# Altium schematic sheet Sensors.SchDoc
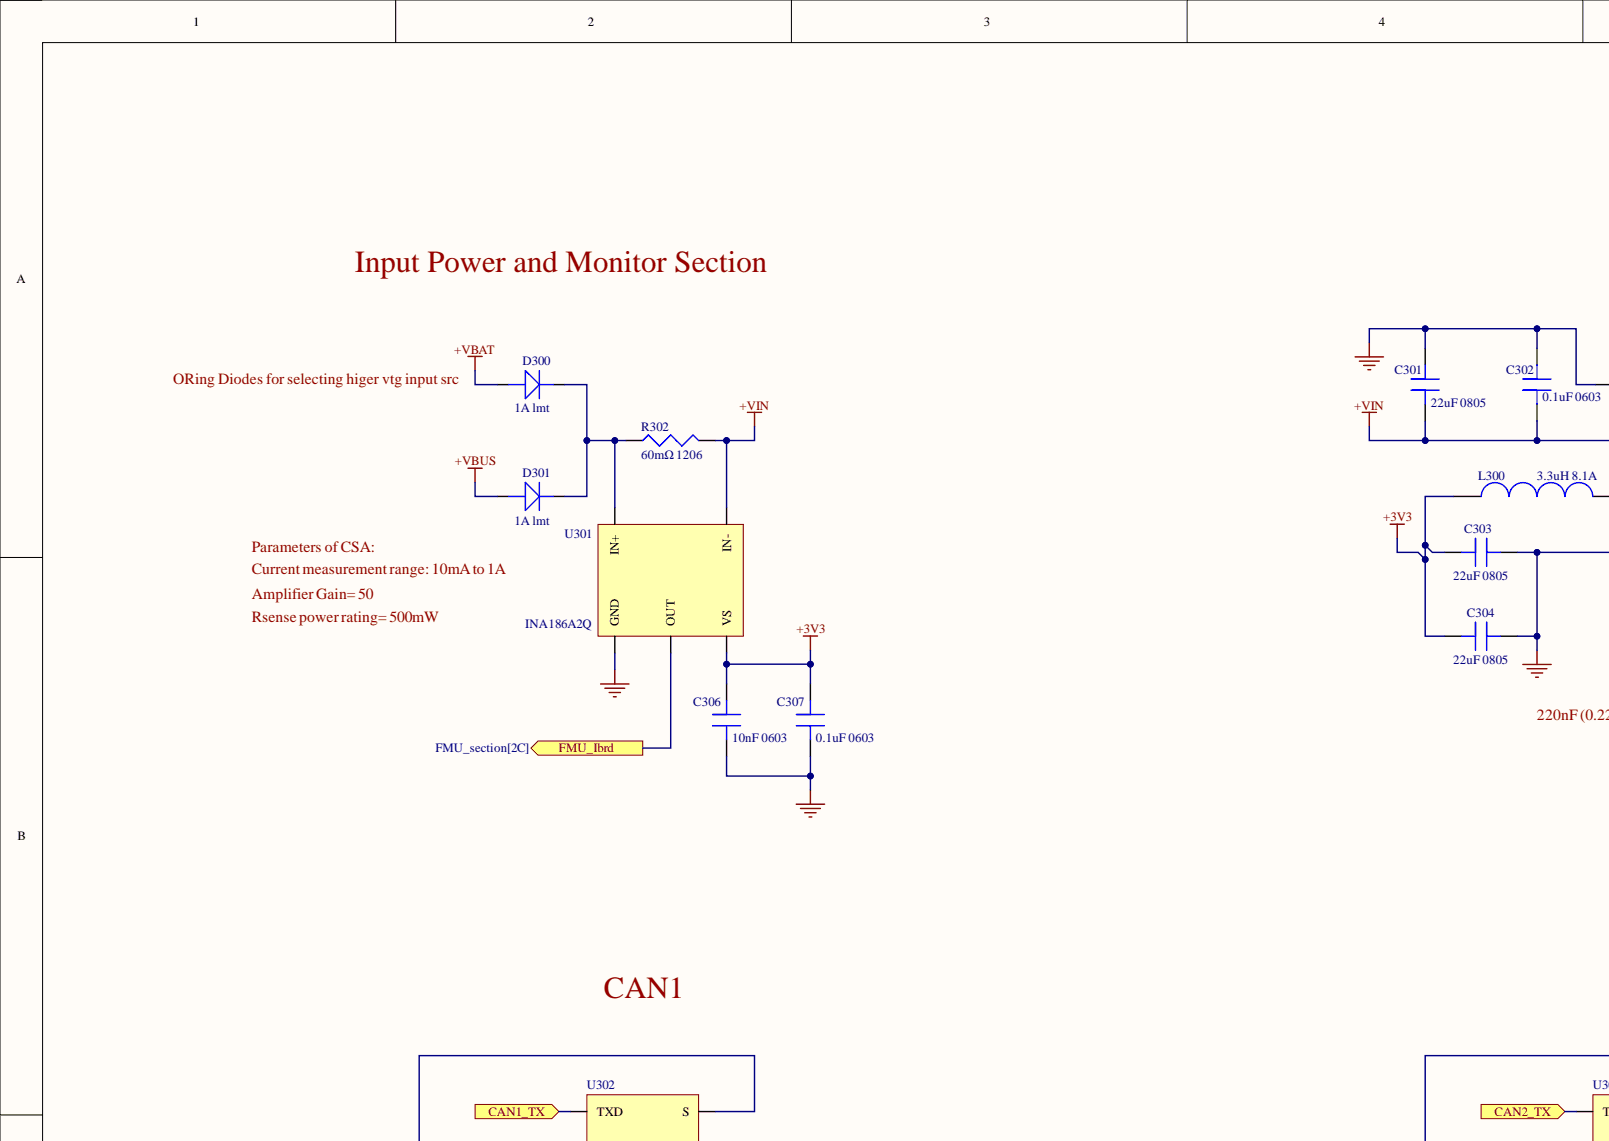
[diagram p0: Sensors.SchDoc, top left]
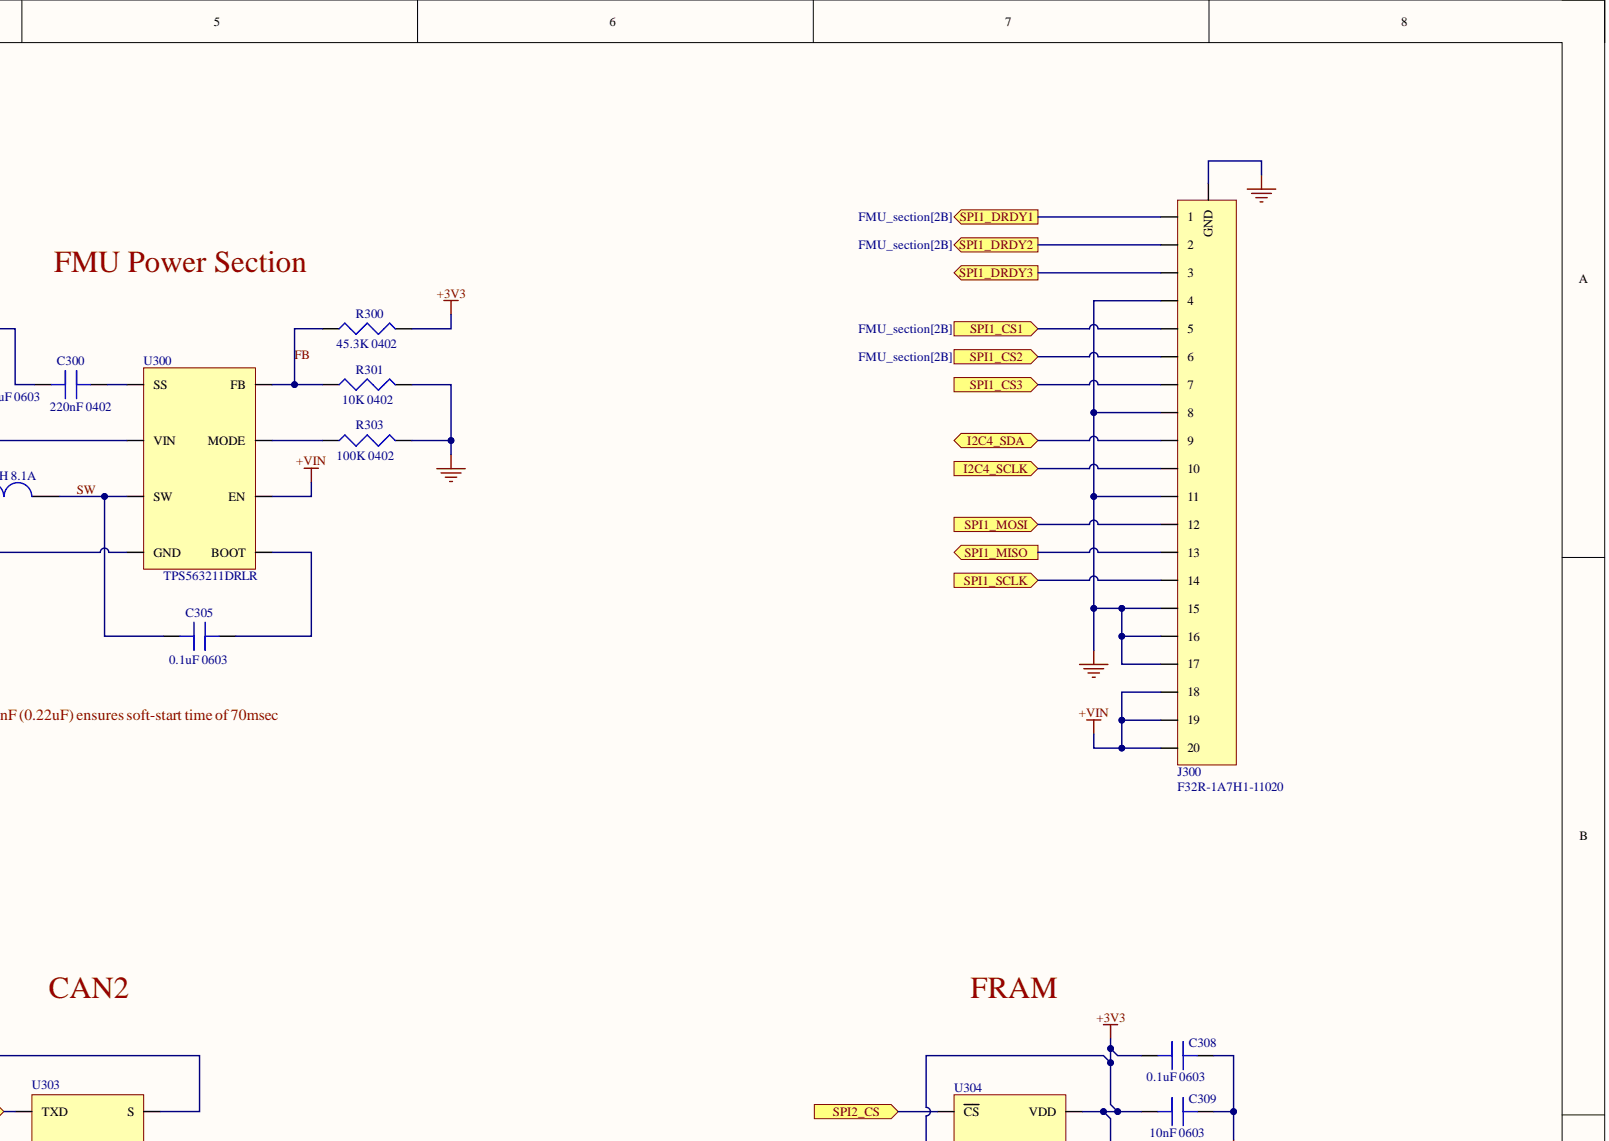
[diagram p1: Sensors.SchDoc, top right]
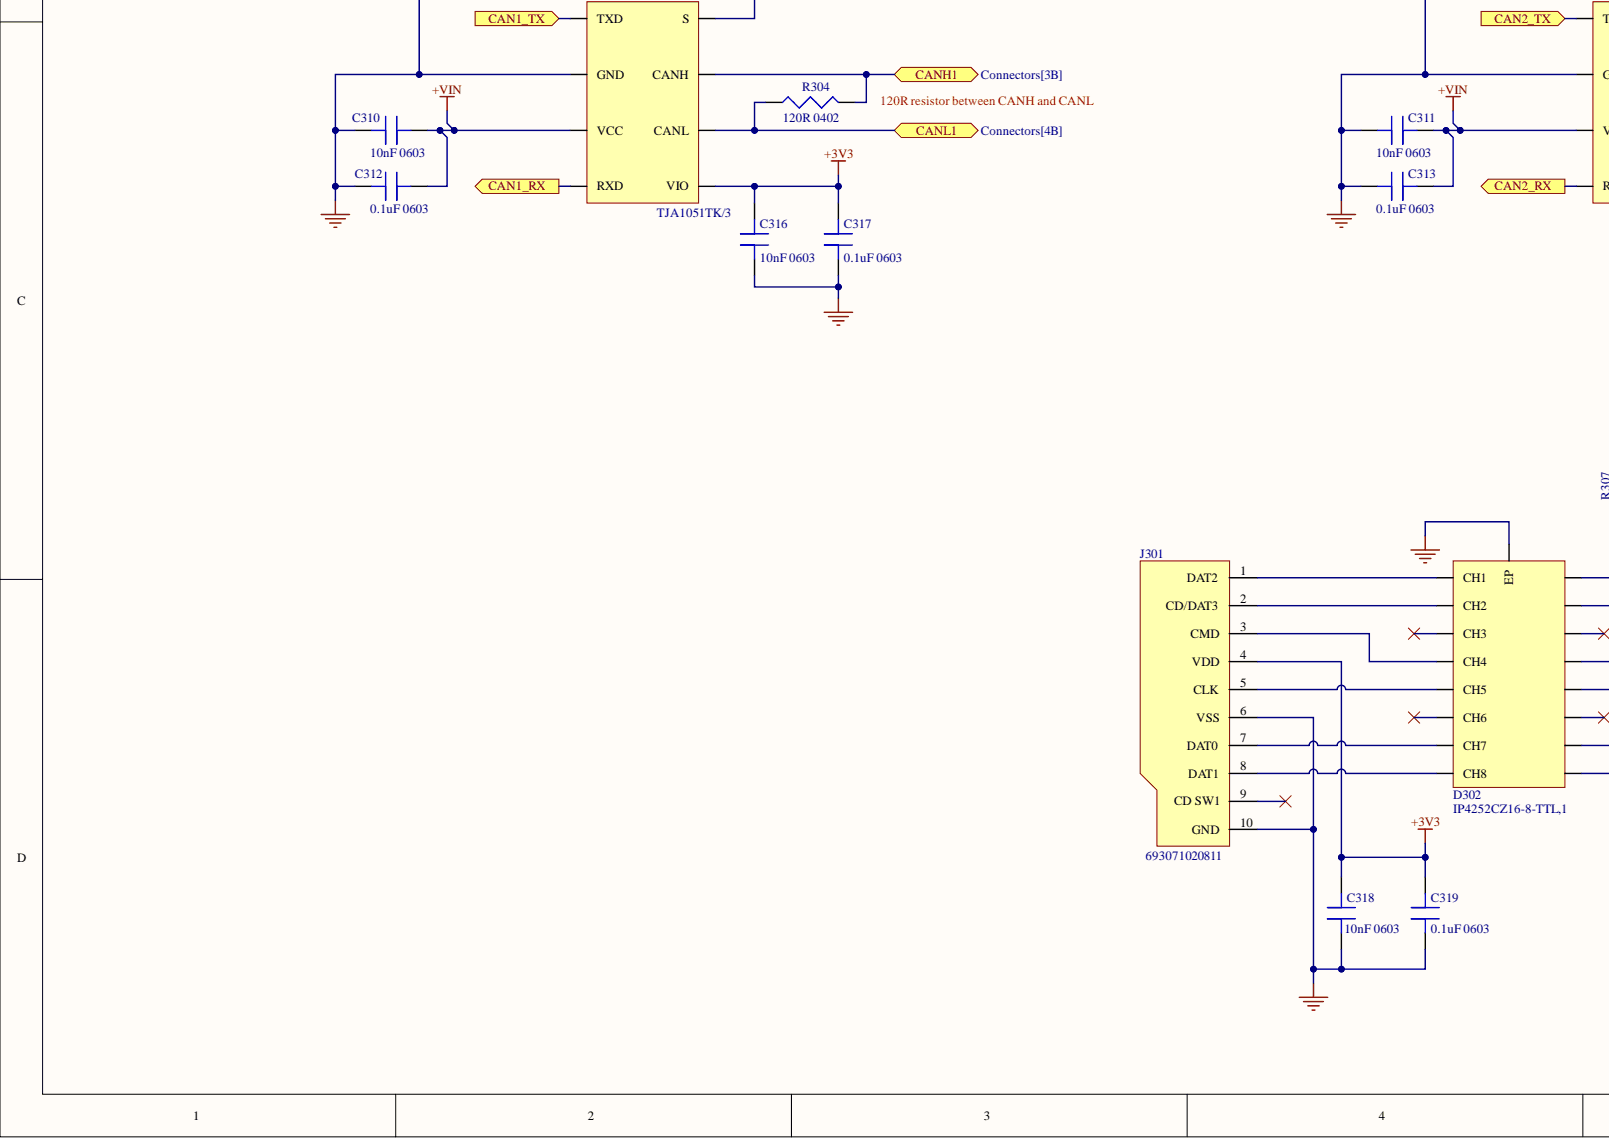
[diagram p2: Sensors.SchDoc, bottom left]
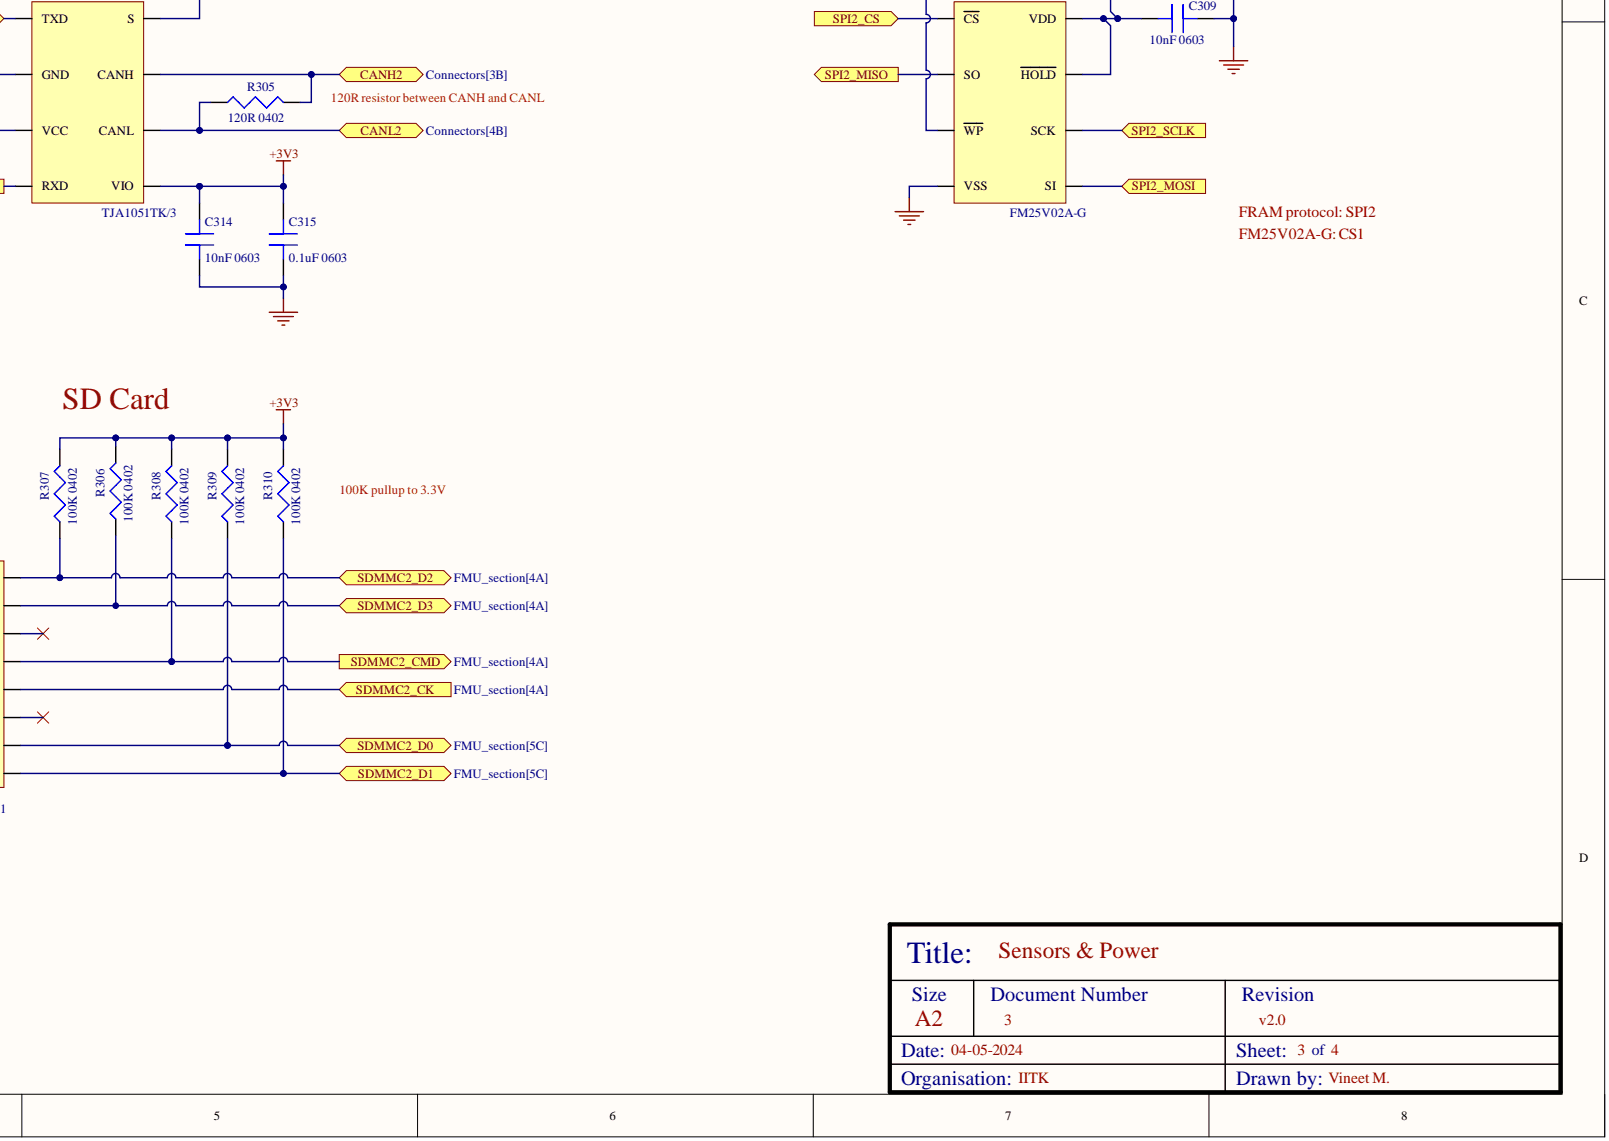
[diagram p3: Sensors.SchDoc, bottom right]

## Parts
C300  220nF 0402  value 220nF  Case/Package: 0402  [GJM15]
C301  22uF 0805  value 22uF  Case/Package: 0805  [GRM21]
C302  0.1uF 0603  [C0603]
C303  22uF 0805  value 22uF  Case/Package: 0805  [GRM21]
C304  22uF 0805  value 22uF  Case/Package: 0805  [GRM21]
C305  0.1uF 0603  Case Code (Imperial): 0603  [C0603]
C306  10nF 0603  Capacitance: 10nF  [C0603]
C307  0.1uF 0603  Case Code (Imperial): 0603  [C0603]
C308  0.1uF 0603  Case Code (Imperial): 0603  [C0603]
C309  10nF 0603  Capacitance: 10nF  Case Code (Imperial): 0603  [C0603]
C310  10nF 0603  Capacitance: 10nF  Case Code (Imperial): 0603  [C0603]
C311  10nF 0603  Capacitance: 10nF  Case Code (Imperial): 0603  [C0603]
C312  0.1uF 0603  Case Code (Imperial): 0603  [C0603]
C313  0.1uF 0603  Case Code (Imperial): 0603  [C0603]
C314  10nF 0603  Capacitance: 10nF  [C0603]
C315  0.1uF 0603  Case Code (Imperial): 0603  [C0603]
C316  10nF 0603  Capacitance: 10nF  [C0603]
C317  0.1uF 0603  Case Code (Imperial): 0603  [C0603]
C318  10nF 0603  Capacitance: 10nF  [C0603]
C319  0.1uF 0603  Case Code (Imperial): 0603  [C0603]
D300  1A lmt  Max Output current: 1A  [S1MB-13-F]
D301  1A lmt  Max Output current: 1A  [S1MB-13-F]
D302  IP4252CZ16-8-TTL,1  [IP4252CZ16-8-TTL]
J300  F32R-1A7H1-11020  [F32R-1A7H1-11020]
J301  693071020811  [693071020811]
L300  3.3uH 8.1A  Inductance-Nom (L): 3.3uH  Max Current Rating: 8.1A  [XAL5030]
R300  45.3K 0402  Case/Package: 0402  [R0402]
R301  10K 0402  Case/Package: 0402  [R0402]
R302  60mΩ 1206  Case/Package: 1206  [WSL1206]
R303  100K 0402  Case/Package: 0402  [R0402]
R304  120R 0402  [R0402]
R305  120R 0402  [R0402]
R306  100K 0402  Case/Package: 0402  [R0402]
R307  100K 0402  Case/Package: 0402  [R0402]
R308  100K 0402  Case/Package: 0402  [R0402]
R309  100K 0402  Case/Package: 0402  [R0402]
R310  100K 0402  Case/Package: 0402  [R0402]
U300  TPS563211DRLR  [TPS563211]
U301  INA186A2Q  [INA186Q]
U302  TJA1051TK/3  [TJA1051TK/3]
U303  TJA1051TK/3  [TJA1051TK/3]
U304  FM25V02A-G  [FM25V02A-G]

## Ports
CAN1_RX, CAN1_TX, CAN2_RX, CAN2_TX, CANH1, CANH2, CANL1, CANL2, FMU_Ibrd, I2C4_SCLK, I2C4_SDA, SDMMC2_CK, SDMMC2_CMD, SDMMC2_D0, SDMMC2_D1, SDMMC2_D2, SDMMC2_D3, SPI1_CS1, SPI1_CS2, SPI1_CS3, SPI1_DRDY1, SPI1_DRDY2, SPI1_DRDY3, SPI1_MISO, SPI1_MOSI, SPI1_SCLK, SPI2_CS, SPI2_MISO, SPI2_MOSI, SPI2_SCLK

## Nets
+3V3: C303.1 C304.1 C306.2 C307.2 C308.2 C309.2 C314.2 C315.2 C316.2 C317.2 C318.2 C319.2 J301.4(VDD) L300.2 R300.2 R306.2 R307.2 R308.2 R309.2 R310.2 U301.3(VS) U302.5(VIO) U303.5(VIO) U304.3(WP) U304.7(HOLD) U304.8(VDD)
+VBAT: D300.2
+VBUS: D301.2
+VIN: C301.1 C302.1 C310.2 C311.2 C312.2 C313.2 J300.18 J300.19 J300.20 R302.2 U300.2(VIN) U300.6(EN) U301.4(IN-) U302.3(VCC) U303.3(VCC)
GND: C300.1 C301.2 C302.2 C303.2 C304.2 C306.1 C307.1 C308.1 C309.1 C310.1 C311.1 C312.1 C313.1 C314.1 C315.1 C316.1 C317.1 C318.1 C319.1 D302.17(EP) J300.4 J300.8 J300.11 J300.15 J300.16 J300.17 J300.21(GND) J301.6(VSS) J301.10(GND) R301.2 R303.2 U300.4(GND) U301.1(GND) U302.2(GND) U302.8(S) U303.2(GND) U303.8(S) U304.4(VSS)
CAN1_RX: U302.4(RXD) ; port CAN1_RX
CAN1_TX: U302.1(TXD) ; port CAN1_TX
CAN2_RX: U303.4(RXD) ; port CAN2_RX
CAN2_TX: U303.1(TXD) ; port CAN2_TX
CANH1: R304.2 U302.7(CANH) ; port CANH1
CANH2: R305.2 U303.7(CANH) ; port CANH2
CANL1: R304.1 U302.6(CANL) ; port CANL1
CANL2: R305.1 U303.6(CANL) ; port CANL2
FB: R300.1 R301.1 U300.8(FB)
FMU_Ibrd: U301.2(OUT) ; port FMU_Ibrd
I2C4_SCLK: J300.10 ; port I2C4_SCLK
I2C4_SDA: J300.9 ; port I2C4_SDA
SDMMC2_CK: D302.5 ; port SDMMC2_CK
SDMMC2_CMD: D302.4 R308.1 ; port SDMMC2_CMD
SDMMC2_D0: D302.7 R309.1 ; port SDMMC2_D0
SDMMC2_D1: D302.8 R310.1 ; port SDMMC2_D1
SDMMC2_D2: D302.1 R307.1 ; port SDMMC2_D2
SDMMC2_D3: D302.2 R306.1 ; port SDMMC2_D3
SPI1_CS1: J300.5 ; port SPI1_CS1
SPI1_CS2: J300.6 ; port SPI1_CS2
SPI1_CS3: J300.7 ; port SPI1_CS3
SPI1_DRDY1: J300.1 ; port SPI1_DRDY1
SPI1_DRDY2: J300.2 ; port SPI1_DRDY2
SPI1_DRDY3: J300.3 ; port SPI1_DRDY3
SPI1_MISO: J300.13 ; port SPI1_MISO
SPI1_MOSI: J300.12 ; port SPI1_MOSI
SPI1_SCLK: J300.14 ; port SPI1_SCLK
SPI2_CS: U304.1(CS) ; port SPI2_CS
SPI2_MISO: U304.2(SO) ; port SPI2_MISO
SPI2_MOSI: U304.5(SI) ; port SPI2_MOSI
SPI2_SCLK: U304.6(SCK) ; port SPI2_SCLK
SW: C305.2 L300.1 U300.3(SW)
NetC300_2: C300.2 U300.1(SS)
NetC305_1: C305.1 U300.5(BOOT)
NetD300_1: D300.1 D301.1 R302.1 U301.5(IN+)
NetD302_9: D302.9(CH8) J301.8(DAT1)
NetD302_10: D302.10(CH7) J301.7(DAT0)
NetD302_12: D302.12(CH5) J301.5(CLK)
NetD302_13: D302.13(CH4) J301.3(CMD)
NetD302_15: D302.15(CH2) J301.2(CD/DAT3)
NetD302_16: D302.16(CH1) J301.1(DAT2)
NetR303_1: R303.1 U300.7(MODE)

Not connected: D302 3 6 11(CH6) 14(CH3); J301 9(CD_SW1)

## Library data of the parts
C300 = GRM155R71C224KA12D: Multilayer Ceramic Capacitors MLCC - SMD/SMT 0.22 uF 16 VDC 10% 0402 X7R; Manufacturer: Murata; Multilayer: Yes; Rated DC Voltage (URdc): 16V; Capacitor Type: Ceramic; Category: Ceramic Capacitors; Component Kind: Standard; ComponentLink1Description: https://datasheet.ciiva.com:443/pdfs/VipMasterIC/IC/MURE/MURE-S-A0008911298/MURE-S-A0008911313-1.pdf?src-supplier=IHS+Markit; ComponentLink1URL: https://datasheet.ciiva.com:443/pdfs/VipMasterIC/IC/MURE/MURE-S-A0008911298/MURE-S-A0008911313-1.pdf?src-supplier=IHS+Markit; ComponentLink2Description: https://datasheet.ciiva.com/132/product-132672.pdf?src-supplier=Digi-Key; ComponentLink2URL: https://datasheet.ciiva.com/132/product-132672.pdf?src-supplier=Digi-Key; ComponentLink3Description: https://datasheet.ciiva.com/18184/getdatasheetpartid-347270-18184668.pdf?src-supplier=Verical; ComponentLink3URL: https://datasheet.ciiva.com/18184/getdatasheetpartid-347270-18184668.pdf?src-supplier=Verical; ComponentLink4Description: https://datasheet.ciiva.com/29199/454127-da-01-en-vs-kondensator-0402-29199807.pdf?src-supplier=Conrad; ComponentLink4URL: https://datasheet.ciiva.com/29199/454127-da-01-en-vs-kondensator-0402-29199807.pdf?src-supplier=Conrad; ComponentLink5Description: https://datasheet.ciiva.com/132/partnumbering-e-01-132671.pdf?src-supplier=Digi-Key; ComponentLink5URL: https://datasheet.ciiva.com/132/partnumbering-e-01-132671.pdf?src-supplier=Digi-Key; ComponentLink6Description: https://datasheet.ciiva.com/132/partnumbering-e-01-132670.pdf?src-supplier=Digi-Key; ComponentLink6URL: https://datasheet.ciiva.com/132/partnumbering-e-01-132670.pdf?src-supplier=Digi-Key; ComponentLink7Description: https://datasheet.ciiva.com/16467/product-16467307.pdf?src-supplier=Future+Electronics; ComponentLink7URL: https://datasheet.ciiva.com/16467/product-16467307.pdf?src-supplier=Future+Electronics; ComponentLink8Description: https://datasheet.ciiva.com/48671/product-48671325.pdf?src-supplier=Avnet; ComponentLink8URL: https://datasheet.ciiva.com/48671/product-48671325.pdf?src-supplier=Avnet; Dielectric Material: Ceramic; Height: 0.5mm; JESD-609 Code: e3; Length: 1mm; Library Name: Components.IntLib; Manufacturer Part Number: GRM155R71C224KA12D; Max Operating Temperature: 125°C; Min Operating Temperature: -55°C; Mounting Technology: Surface Mount; Package Shape: Rectangular; Package Style: SMTMeter; Packaging: Tape and Reel; Pins: 2; Pricing: 1=0.1, 10=0.067, 50=0.0366, 100=0.0299, 500=0.02134, 1000=0.01677, 2500=0.01524, 5000=0.01402 (USD); Reach SVHC Compliant: Yes; RoHS: Yes; RoHS Compliant: Yes; Stock: 1175284; Supplier: Digi-Key; Supplier Part Number: 490-5418-1-ND; Temperature Characteristics Code: X7R; Terminal Finish: Nickel; Termination: Wraparound; Tolerance  (fill in as +-): 10%; Tolerance (fill in as +-): 10%; Width: 0.5mm
C301 C303 C304 = GRM21BR61E226ME44L: Multilayer Ceramic Capacitors MLCC - SMD/SMT 22 uF 25 VDC 20% 0805 X5R; Manufacturer: Murata; Multilayer: Yes; Rated DC Voltage (URdc): 25V; Capacitor Type: Ceramic; Category: Ceramic Capacitors; Component Kind: Standard; ComponentLink1Description: https://datasheet.ciiva.com:443/pdfs/VipMasterIC/IC/MURE/MURE-S-A0008911298/MURE-S-A0008911306-1.pdf?src-supplier=IHS+Markit; ComponentLink1URL: https://datasheet.ciiva.com:443/pdfs/VipMasterIC/IC/MURE/MURE-S-A0008911298/MURE-S-A0008911306-1.pdf?src-supplier=IHS+Markit; ComponentLink2Description: https://datasheet.ciiva.com/22787/1747471-22787265.pdf?src-supplier=Farnell; ComponentLink2URL: https://datasheet.ciiva.com/22787/1747471-22787265.pdf?src-supplier=Farnell; ComponentLink3Description: https://datasheet.ciiva.com/7719/1747471-7719095.pdf?src-supplier=Element14; ComponentLink3URL: https://datasheet.ciiva.com/7719/1747471-7719095.pdf?src-supplier=Element14; ComponentLink4Description: https://datasheet.ciiva.com/28584/1747471-28584199.pdf?src-supplier=Element14; ComponentLink4URL: https://datasheet.ciiva.com/28584/1747471-28584199.pdf?src-supplier=Element14; Dielectric Material: Ceramic; Height: 1.25mm; JESD-609 Code: e3; Length: 2mm; Library Name: Components.IntLib; Manufacturer Part Number: GRM21BR61E226ME44L; Max Operating Temperature: 85°C; Min Operating Temperature: -55°C; Mounting Technology: Surface Mount; Package Shape: Rectangular; Package Style: SMTMeter; Packaging: Tape and Reel; Pins: 2; Pricing: 1=0.33, 10=0.16, 50=0.16, 100=0.103, 1000=0.087, 10000=0.07 (USD); Reach SVHC Compliant: Yes; RoHS: Yes; RoHS Compliant: Yes; Stock: 1110967; Supplier: Mouser; Supplier Part Number: 81-GRM21BR61E226ME4L; Temperature Characteristics Code: X5R; Terminal Finish: Nickel; Termination: Wraparound; Tolerance  (fill in as +-): 20%; Tolerance (fill in as +-): 20%; Width: 1.25mm
C302 C305 C307 C308 C312 C313 C315 C317 C319 = C0603C104K5RACTU: 0.1µF ±10% 50V Ceramic Capacitor X7R 0603 (1608 Metric); Manufacturer: KEMET; Capacitance: 100nF; Dissipation Factor: 2.5%; Case Code (Metric): 1608; Case/Package: 0603; Category: Ceramic Capacitors; Component Kind: Standard; ComponentLink1Description: https://datasheet.ciiva.com/7823/kem-c1002-x7r-smd-7823591.pdf?src-supplier=Element14; ComponentLink1URL: https://datasheet.ciiva.com/7823/kem-c1002-x7r-smd-7823591.pdf?src-supplier=Element14; ComponentLink2Description: https://datasheet.ciiva.com/14602/70095383-14602583.pdf?src-supplier=Allied+Electronics; ComponentLink2URL: https://datasheet.ciiva.com/14602/70095383-14602583.pdf?src-supplier=Allied+Electronics; ComponentLink3Description: https://datasheet.ciiva.com/5999/kem-c1002-x7r-smd-5999400.pdf?src-supplier=Farnell; ComponentLink3URL: https://datasheet.ciiva.com/5999/kem-c1002-x7r-smd-5999400.pdf?src-supplier=Farnell; ComponentLink4Description: https://datasheet.ciiva.com/7823/kem-c1002-x7r-smd-7823592.pdf?src-supplier=Element14; ComponentLink4URL: https://datasheet.ciiva.com/7823/kem-c1002-x7r-smd-7823592.pdf?src-supplier=Element14; ComponentLink5Description: https://datasheet.ciiva.com/28645/1851736-28645640.pdf?src-supplier=Element14; ComponentLink5URL: https://datasheet.ciiva.com/28645/1851736-28645640.pdf?src-supplier=Element14; ComponentLink6Description: https://datasheet.ciiva.com/14585/70095383-14585209.pdf?src-supplier=Allied+Electronics; ComponentLink6URL: https://datasheet.ciiva.com/14585/70095383-14585209.pdf?src-supplier=Allied+Electronics; Contact Plating: Tin,Matte; Depth: 0.8mm; Dielectric: X7R; Dielectric Material: Ceramic; Height: 0.8mm; Lead Pitch: 0.7mm; Length: 0.063inch; Library Name: Components.IntLib; Manufacturer Part Number: C0603C104K5RACTU; Max Operating Temperature: 125°C; Min Operating Temperature: -55°C; Mount: Surface Mount; Number of Pins: 2; Package Quantity: 1; Packaging: Tape and Reel; Pricing 1: 1=0.12000, 10=0.08900, 50=0.04840, 100=0.03940, 500=0.02818, 1000=0.02214 (USD); Radiation Hardening: No; REACH SVHC: No SVHC; RoHS: Yes; RoHS Compliant: Yes; Series: SMD Comm X7R; Stock 1: 3160568; Supplier 1: Digi-Key; Supplier Part Number 1: 399-5089-1-ND; Termination: SMD/SMT; Thickness: 0.034inch; Tolerance: 10%; Voltage: 50V; Voltage Rating: 50V; Voltage Rating (DC): 50V; Width: 0.031inch
  C302: Case Code (Imperial): 0603
C306 C309-C311 C314 C316 C318 = C0603C103K5RACTU: 10000pF ±10% 50V Ceramic Capacitor X7R 0603 (1608 Metric); Manufacturer: KEMET; Dissipation Factor: 2.5%; Case Code (Metric): 1608; Case/Package: 0603; Category: Ceramic Capacitors; Component Kind: Standard; ComponentLink1Description: https://datasheet.ciiva.com/7823/1732718-7823585.pdf?src-supplier=Element14; ComponentLink1URL: https://datasheet.ciiva.com/7823/1732718-7823585.pdf?src-supplier=Element14; ComponentLink2Description: https://datasheet.ciiva.com/5904/kem-c1002-x7r-smd-5904645.pdf?src-supplier=Farnell; ComponentLink2URL: https://datasheet.ciiva.com/5904/kem-c1002-x7r-smd-5904645.pdf?src-supplier=Farnell; ComponentLink3Description: https://datasheet.ciiva.com/18192/getdatasheetpartid-308803-18192662.pdf?src-supplier=Verical; ComponentLink3URL: https://datasheet.ciiva.com/18192/getdatasheetpartid-308803-18192662.pdf?src-supplier=Verical; ComponentLink4Description: https://datasheet.ciiva.com/39122/kem-c1002-x7r-smd-39122903.pdf?src-supplier=Onlinecomponents.com; ComponentLink4URL: https://datasheet.ciiva.com/39122/kem-c1002-x7r-smd-39122903.pdf?src-supplier=Onlinecomponents.com; ComponentLink5Description: https://datasheet.ciiva.com/7823/kem-c1002-x7r-smd-7823976.pdf?src-supplier=Element14; ComponentLink5URL: https://datasheet.ciiva.com/7823/kem-c1002-x7r-smd-7823976.pdf?src-supplier=Element14; ComponentLink6Description: https://datasheet.ciiva.com/7823/kem-c1002-x7r-smd-7823977.pdf?src-supplier=Element14; ComponentLink6URL: https://datasheet.ciiva.com/7823/kem-c1002-x7r-smd-7823977.pdf?src-supplier=Element14; ComponentLink7Description: https://datasheet.ciiva.com/7823/1918693-7823978.pdf?src-supplier=Element14; ComponentLink7URL: https://datasheet.ciiva.com/7823/1918693-7823978.pdf?src-supplier=Element14; ComponentLink8Description: https://datasheet.ciiva.com/14585/70095383-14585450.pdf?src-supplier=Allied+Electronics; ComponentLink8URL: https://datasheet.ciiva.com/14585/70095383-14585450.pdf?src-supplier=Allied+Electronics; ComponentLink9Description: https://datasheet.ciiva.com/18101/getdatasheetpartid-58468-18101898.pdf?src-supplier=Verical; ComponentLink9URL: https://datasheet.ciiva.com/18101/getdatasheetpartid-58468-18101898.pdf?src-supplier=Verical; ComponentLink10Description: https://datasheet.ciiva.com/5416/kem-c1002-x7r-smd-5416028.pdf?src-supplier=Mouser; ComponentLink10URL: https://datasheet.ciiva.com/5416/kem-c1002-x7r-smd-5416028.pdf?src-supplier=Mouser; ComponentLink11Description: https://datasheet.ciiva.com/28645/1851736-28645598.pdf?src-supplier=Element14; ComponentLink11URL: https://datasheet.ciiva.com/28645/1851736-28645598.pdf?src-supplier=Element14; Contact Plating: Tin,Matte; Depth: 0.8mm; Dielectric: X7R; Dielectric Material: Ceramic; Height: 0.8mm; Lead Pitch: 0.7mm; Length: 1.6mm; Library Name: Components.IntLib; Manufacturer Part Number: C0603C103K5RACTU; Max Operating Temperature: 125°C; Min Operating Temperature: -55°C; Mount: Surface Mount,PCB; Number of Pins: 2; Package Quantity: 4000; Packaging: Tape and Reel; Pricing 1: 1=0.10000, 10=0.07000, 50=0.03820, 100=0.03130, 500=0.02234, 1000=0.01755 (USD); Radiation Hardening: No; REACH SVHC: No SVHC; RoHS: Yes; Stock 1: 1389959; Supplier 1: Digi-Key; Supplier Part Number 1: 399-1091-1-ND; Termination: SMD/SMT; Thickness: 0.034inch; Tolerance: 10%; Voltage: 50V; Voltage Rating: 50V; Voltage Rating (DC): 50V; Width: 0.8mm
  C306 C314 C316 C318: Case Code (Imperial): 0603
D300 D301 = S1MB-13-F: Diode 1000 V 1A Surface Mount SMB; Manufacturer: Diodes; Additional Feature: HIGH RELIABILITY; Diode Element Material: SILICON; Diode Type: RECTIFIER; Forward Voltage: 1.1V; Non-rep Pk Forward Current-Max: 30A; Number of Elements: 1; Package Body Material: Plastic; Qualification Status: Not Qualified; Rep Pk Reverse Voltage-Max: 1kV; Reverse Recovery Time-Max: 3us; Time @ Peak Reflow Temperature-Max (s): 40s; Category: Rectifier Diodes; Component Kind: Standard; ComponentLink1Description: https://datasheet.ciiva.com:443/pdfs/VipMasterIC/IC/DIOD/DIOD-S-A0007810797/DIOD-S-A0007810797-1.pdf?src-supplier=IHS+Markit; ComponentLink1URL: https://datasheet.ciiva.com:443/pdfs/VipMasterIC/IC/DIOD/DIOD-S-A0007810797/DIOD-S-A0007810797-1.pdf?src-supplier=IHS+Markit; ComponentLink2Description: https://datasheet.ciiva.com/912/ds16003-912422.pdf?src-supplier=Digi-Key; ComponentLink2URL: https://datasheet.ciiva.com/912/ds16003-912422.pdf?src-supplier=Digi-Key; ComponentLink3Description: https://datasheet.ciiva.com/912/ds16003-912423.pdf?src-supplier=Digi-Key; ComponentLink3URL: https://datasheet.ciiva.com/912/ds16003-912423.pdf?src-supplier=Digi-Key; JESD-30 Code: R-PDSO-C2; JESD-609 Code: e3; Library Name: Components.IntLib; Manufacturer Part Number: S1MB-13-F; Max Operating Temperature: 150°C; Min Operating Temperature: -65°C; Moisture Sensitivity Level: 1; Package Shape: Rectangular; Package Style: SMALL OUTLINEMeter; Peak Reflow Temperature (Cel): 260°C; Pins: 2; Pricing: 1=0.38, 10=0.308, 100=0.1635, 500=0.10756, 1000=0.07314 (USD); Reach SVHC Compliant: No; RoHS: Yes; RoHS Compliant: Yes; Stock: 1245024; Supplier: Digi-Key; Supplier Part Number: S1MB-FDICT-ND; Surface Mount: Yes; Terminal Finish: Tin; Terminal Form: C BEND; Terminal Position: DUAL
D302 = IP4252CZ16-8-TTL,1: RC (Pi) EMI Filter 2nd Order Low Pass 8 Channel R = 40Ohms, C = 12pF (Total) 16-UFDFN Exposed Pad; Manufacturer: Nexperia; Category: ESD and Circuit Protection ICs; Component Kind: Standard; ComponentLink1Description: http://www.farnell.com/datasheets/1443361.pdf?src-supplier=Farnell; ComponentLink1URL: http://www.farnell.com/datasheets/1443361.pdf?src-supplier=Farnell; ComponentLink2Description: https://datasheet.octopart.com/IP4252CZ16-8-TTL%2C1-Nexperia-datasheet-10407563.pdf?src-supplier=Newark; ComponentLink2URL: https://datasheet.octopart.com/IP4252CZ16-8-TTL%2C1-Nexperia-datasheet-10407563.pdf?src-supplier=Newark; ComponentLink3Description: https://assets.nexperia.com/documents/data-sheet/IP4251_52_53_54-TTL.pdf?src-supplier=Digi-Key; ComponentLink3URL: https://assets.nexperia.com/documents/data-sheet/IP4251_52_53_54-TTL.pdf?src-supplier=Digi-Key; ComponentLink4Description: https://datasheet.octopart.com/IP4252CZ16-8-TTL%2C1-Nexperia-datasheet-87581341.pdf?src-supplier=LKR; ComponentLink4URL: https://datasheet.octopart.com/IP4252CZ16-8-TTL%2C1-Nexperia-datasheet-87581341.pdf?src-supplier=LKR; Library Name: Components.SchLib; Manufacturer Part Number: IP4252CZ16-8-TTL,1; Pricing: 1=0.34, 10=0.328, 25=0.3176, 50=0.307, 100=0.2912, 250=0.24352, 500=0.189, 1000=0.17999 (USD); RoHS: Yes; RoHS Compliant: Yes; Stock: 88465; Supplier: Digi-Key; Supplier Part Number: 1727-5675-1-ND
J300 = F32R-1A7H1-11020: 20 Position FFC, FPC Connector Contacts, Bottom 0.020" (0.50mm) Surface Mount, Right Angle; Manufacturer: Amphenol ICC / FCI; Category: FFC / FPC; Component Kind: Standard; Library Name: Components.SchLib; Manufacturer Part Number: F32R-1A7H1-11020; Pricing: 1=0.73, 10=0.633, 25=0.578, 50=0.5442, 100=0.5215, 250=0.47616, 500=0.45348 (USD); RoHS: No; Stock: 27715; Supplier: Digi-Key; Supplier Part Number: 609-F32R-1A7H1-11020CT-ND
J301 = 693071020811: 9 (8 + 1) Position Card Connector Secure Digital - microSD™ Surface Mount, Right Angle Gold; Manufacturer: Wurth Elektronik; Contact Resistance: 100mOhm; Gender: Socket; Match Code: WR-CRD; Rated Current: 0.5A; Withstanding Voltage: 500VAC; Working Voltage: 30VAC; Category: Input/Output Connectors; Component Kind: Standard; ComponentLink1Description: Website Link; ComponentLink1URL: https://www.we-online.com/redexpert/article/693071020811?ad; ComponentLink2Description: Datasheet Link; ComponentLink2URL: https://www.we-online.com/redexpert/spec/693071020811?ad; Library Name: Components.SchLib; Manufacturer Part Number: 693071020811; Mount: Surface Mount; Number of Pins: 8; Operating Temperature Max: 85°C; Operating Temperature Min: -40°C; Packaging: Tape and Reel; Type: Push & Push
L300 = XAL5030-332MEC: Power Inductors - SMD 3.3uH Shld 20% 8.1A 23.3mOhms AECQ2; Manufacturer: Coilcraft; Case/Size Code: 2221; Channels: 1; Core Material: Composite; DC Resistance (DCR): 23.3mR; Inductor Type: GENERAL PURPOSE INDUCTOR; Package Height: 3.1mm; Package Length: 5.48mm; Package Width: 5.28mm; Reference Standard: AEC-Q200; Self Resonant Frequency: 28MHz; Shape/Size Description: RECTANGULAR PACKAGE; Shielding: Shielded; Test Frequency: 100kHz; Category: Fixed Inductors; Component Kind: Standard; ComponentLink1Description: https://datasheet.ciiva.com:443/pdfs/VipMasterIC/IC/COLC/COLC-S-A0006757246/COLC-S-A0006757246-1.pdf?src-supplier=IHS+Markit; ComponentLink1URL: https://datasheet.ciiva.com:443/pdfs/VipMasterIC/IC/COLC/COLC-S-A0006757246/COLC-S-A0006757246-1.pdf?src-supplier=IHS+Markit; ComponentLink2Description: https://datasheet.ciiva.com/22680/1681990-22680358.pdf?src-supplier=Element14; ComponentLink2URL: https://datasheet.ciiva.com/22680/1681990-22680358.pdf?src-supplier=Element14; ComponentLink3Description: https://datasheet.ciiva.com/22701/1681990-22701567.pdf?src-supplier=Element14; ComponentLink3URL: https://datasheet.ciiva.com/22701/1681990-22701567.pdf?src-supplier=Element14; ComponentLink4Description: https://datasheet.ciiva.com/22680/1681990-22680359.pdf?src-supplier=Element14; ComponentLink4URL: https://datasheet.ciiva.com/22680/1681990-22680359.pdf?src-supplier=Element14; ComponentLink5Description: https://datasheet.ciiva.com/13279/xal50xx-13279273.pdf?src-supplier=Mouser; ComponentLink5URL: https://datasheet.ciiva.com/13279/xal50xx-13279273.pdf?src-supplier=Mouser; Construction: Chip; Inductor Application: POWER INDUCTOR; JESD-609 Code: e2; Library Name: Components.IntLib; Manufacturer Part Number: XAL5030-332MEC; Max Operating Temperature: 125°C; Min Operating Temperature: -40°C; Package Style: SMTMeter; Packaging: Tape and Reel; Pins: 2; Pricing 1: 1=2.88, 10=2.88, 50=2.88, 100=2.88, 1000=2.02, 10000=2.02 (USD); Reach SVHC Compliant: Yes; RoHS: Yes; RoHS Compliant: Yes; Stock 1: 30660; Supplier 1: Mouser; Supplier Part Number 1: 994-XAL5030-332MEC; Surface Mount: Yes; Terminal Finish: Tin; Terminal Placement: Dual Ended; Termination: One Surface; Tolerance: 20%
R300 = CRCW040245K3FKED: Thick Film Resistors - SMD 1/16watt 45.3Kohms 1%; Manufacturer: Vishay; Package Height: 0.35mm; Package Length: 1mm; Package Width: 0.5mm; Power: 63mW; Rated Temperature: 70°C; Reference Standard: AEC-Q200; Temperature Coefficient: 100ppm/°C; Value: 45.3kR; Working Voltage: 50V; Category: Chip SMD Resistors; Component Kind: Standard; ComponentLink1Description: https://datasheet.ciiva.com:443/pdfs/VipMasterIC/IC/VISH/VISH-S-A0007266705/VISH-S-A0007266705-1.pdf?src-supplier=IHS+Markit; ComponentLink1URL: https://datasheet.ciiva.com:443/pdfs/VipMasterIC/IC/VISH/VISH-S-A0007266705/VISH-S-A0007266705-1.pdf?src-supplier=IHS+Markit; ComponentLink2Description: https://datasheet.ciiva.com/16762/70201119-16762876.pdf?src-supplier=Allied+Electronics; ComponentLink2URL: https://datasheet.ciiva.com/16762/70201119-16762876.pdf?src-supplier=Allied+Electronics; ComponentLink3Description: https://datasheet.ciiva.com/3256/dcrcwe3-3256540.pdf?src-supplier=Avnet; ComponentLink3URL: https://datasheet.ciiva.com/3256/dcrcwe3-3256540.pdf?src-supplier=Avnet; ComponentLink4Description: https://datasheet.ciiva.com/2464/dcrcwe3-2464538.pdf?src-supplier=Digi-Key; ComponentLink4URL: https://datasheet.ciiva.com/2464/dcrcwe3-2464538.pdf?src-supplier=Digi-Key; ComponentLink5Description: https://datasheet.ciiva.com/2464/dcrcwe3-2464539.pdf?src-supplier=Digi-Key; ComponentLink5URL: https://datasheet.ciiva.com/2464/dcrcwe3-2464539.pdf?src-supplier=Digi-Key; ComponentLink6Description: https://datasheet.ciiva.com/2464/dcrcwe3-2464537.pdf?src-supplier=Digi-Key; ComponentLink6URL: https://datasheet.ciiva.com/2464/dcrcwe3-2464537.pdf?src-supplier=Digi-Key; ComponentLink7Description: https://datasheet.ciiva.com/16393/dcrcwe3-16393613.pdf?src-supplier=Future+Electronics; ComponentLink7URL: https://datasheet.ciiva.com/16393/dcrcwe3-16393613.pdf?src-supplier=Future+Electronics; Construction: Chip; JESD-609 Code: e3; Library Name: Components.IntLib; Manufacturer Part Number: CRCW040245K3FKED; Max Operating Temperature: 155°C; Min Operating Temperature: -55°C; Mounting Technology: Surface Mount; Package Shape: Rectangular; Package Style: SMTMeter; Packaging: Tape and Reel; Pins: 2; Pricing: 1=0.1, 10=0.019, 50=0.019, 100=0.009, 1000=0.006, 10000=0.004 (USD); Reach SVHC Compliant: Yes; Resistor Type: FIXED RESISTOR; RoHS: Yes; RoHS Compliant: Yes; Stock: 33855; Supplier: Mouser; Supplier Part Number: 71-CRCW0402-45.3K-E3; Technology: METAL GLAZE/THICK FILM; Terminal Finish: Nickel; Termination: Wraparound; Tolerance: 1%
R301 = CRCW040210K0FKED: Thick Film Resistors - SMD 1/16watt 10Kohms 1%; Manufacturer: Vishay; Package Height: 0.35mm; Package Length: 1mm; Package Width: 0.5mm; Power: 63mW; Rated Temperature: 70°C; Reference Standard: AEC-Q200; Temperature Coefficient: 100ppm/°C; Value: 10kR; Working Voltage: 50V; Category: Chip SMD Resistors; Component Kind: Standard; ComponentLink1Description: https://datasheet.ciiva.com:443/pdfs/VipMasterIC/IC/VISH/VISH-S-A0007266705/VISH-S-A0007266705-1.pdf?src-supplier=IHS+Markit; ComponentLink1URL: https://datasheet.ciiva.com:443/pdfs/VipMasterIC/IC/VISH/VISH-S-A0007266705/VISH-S-A0007266705-1.pdf?src-supplier=IHS+Markit; ComponentLink2Description: http://www.farnell.com/datasheets/2714353.pdf?src-supplier=Farnell; ComponentLink2URL: http://www.farnell.com/datasheets/2714353.pdf?src-supplier=Farnell; ComponentLink3Description: http://www.farnell.com/datasheets/2793682.pdf?src-supplier=Farnell; ComponentLink3URL: http://www.farnell.com/datasheets/2793682.pdf?src-supplier=Farnell; ComponentLink4Description: http://www.farnell.com/datasheets/2714353.pdf?src-supplier=Newark; ComponentLink4URL: http://www.farnell.com/datasheets/2714353.pdf?src-supplier=Newark; ComponentLink5Description: https://datasheet.octopart.com/CRCW040210K0FKED-Vishay-datasheet-5300368.pdf?src-supplier=Future+Electronics; ComponentLink5URL: https://datasheet.octopart.com/CRCW040210K0FKED-Vishay-datasheet-5300368.pdf?src-supplier=Future+Electronics; ComponentLink6Description: http://datasheet.octopart.com/CRCW040210K0FKED-Vishay-datasheet-62317933.pdf?src-supplier=Digi-Key; ComponentLink6URL: http://datasheet.octopart.com/CRCW040210K0FKED-Vishay-datasheet-62317933.pdf?src-supplier=Digi-Key; ComponentLink7Description: https://datasheet.octopart.com/CRCW040210K0FKED-Vishay-datasheet-83961561.pdf?src-supplier=Element14; ComponentLink7URL: https://datasheet.octopart.com/CRCW040210K0FKED-Vishay-datasheet-83961561.pdf?src-supplier=Element14; ComponentLink8Description: http://www.farnell.com/datasheets/2714353.pdf?src-supplier=Element14; ComponentLink8URL: http://www.farnell.com/datasheets/2714353.pdf?src-supplier=Element14; ComponentLink9Description: http://datasheet.octopart.com/CRCW040210K0FKED-Vishay-datasheet-62317933.pdf?src-supplier=Rs; ComponentLink9URL: http://datasheet.octopart.com/CRCW040210K0FKED-Vishay-datasheet-62317933.pdf?src-supplier=Rs; ComponentLink10Description: http://datasheet.octopart.com/CRCW040210K0FKED-Vishay-datasheet-62317933.pdf?src-supplier=Avnet; ComponentLink10URL: http://datasheet.octopart.com/CRCW040210K0FKED-Vishay-datasheet-62317933.pdf?src-supplier=Avnet; ComponentLink11Description: http://www.farnell.com/datasheets/2793682.pdf?src-supplier=Newark; ComponentLink11URL: http://www.farnell.com/datasheets/2793682.pdf?src-supplier=Newark; ComponentLink12Description: https://datasheet.octopart.com/CRCW040210K0FKED.-Vishay-datasheet-78776209.pdf?src-supplier=Newark; ComponentLink12URL: https://datasheet.octopart.com/CRCW040210K0FKED.-Vishay-datasheet-78776209.pdf?src-supplier=Newark; ComponentLink13Description: http://datasheet.octopart.com/CRCW040210K0FKED-Vishay-datasheet-62317933.pdf?src-supplier=Distrelec; ComponentLink13URL: http://datasheet.octopart.com/CRCW040210K0FKED-Vishay-datasheet-62317933.pdf?src-supplier=Distrelec; ComponentLink14Description: http://datasheet.octopart.com/CRCW040210K0FKED-Vishay-datasheet-62317933.pdf?src-supplier=Mouser; ComponentLink14URL: http://datasheet.octopart.com/CRCW040210K0FKED-Vishay-datasheet-62317933.pdf?src-supplier=Mouser; ComponentLink15Description: http://datasheet.octopart.com/CRCW040210K0FKED-Vishay-datasheet-62317933.pdf?src-supplier=Quest; ComponentLink15URL: http://datasheet.octopart.com/CRCW040210K0FKED-Vishay-datasheet-62317933.pdf?src-supplier=Quest; ComponentLink16Description: http://datasheet.octopart.com/CRCW040210K0FKED-Vishay-datasheet-62317933.pdf?src-supplier=TTI; ComponentLink16URL: http://datasheet.octopart.com/CRCW040210K0FKED-Vishay-datasheet-62317933.pdf?src-supplier=TTI; ComponentLink17Description: http://datasheet.octopart.com/CRCW040210K0FKED-Vishay-datasheet-62317933.pdf?src-supplier=Freelance+Electronics; ComponentLink17URL: http://datasheet.octopart.com/CRCW040210K0FKED-Vishay-datasheet-62317933.pdf?src-supplier=Freelance+Electronics; ComponentLink18Description: https://datasheet.octopart.com/CRCW040210K0FKED-Vishay-datasheet-134526670.pdf?src-supplier=TME; ComponentLink18URL: https://datasheet.octopart.com/CRCW040210K0FKED-Vishay-datasheet-134526670.pdf?src-supplier=TME; Construction: Chip; JESD-609 Code: e3; Library Name: Components.IntLib; Manufacturer Part Number: CRCW040210K0FKED; Max Operating Temperature: 155°C; Min Operating Temperature: -55°C; Mounting Technology: Surface Mount; Package Shape: Rectangular; Package Style: SMTMeter; Packaging: Tape and Reel; Pins: 2; Pricing: 1=0.1, 10=0.019, 50=0.019, 100=0.009, 1000=0.006, 10000=0.003 (USD); Reach SVHC Compliant: Yes; Resistor Type: FIXED RESISTOR; RoHS: Yes; RoHS Compliant: Yes; Stock: 1050191; Supplier: Mouser; Supplier Part Number: 71-CRCW0402-10K-E3; Technology: METAL GLAZE/THICK FILM; Terminal Finish: Nickel; Termination: Wraparound; Tolerance: 1%
R302 = WSL1206R0600FEA18: 60 mOhms ±1% 0.5W, 1/2W Chip Resistor 1206 (3216 Metric) Automotive AEC-Q200, Current Sense, Moisture Resistant, Pulse Withstanding Metal Element; Manufacturer: Vishay Dale; Package Height: 0.635mm; Package Length: 3.2mm; Package Width: 1.6mm; Power: 500mW; Rated Temperature: 70°C; Temperature Coefficient: 75ppm/°C; Value: 60mR; Category: Chip SMD Resistors; Component Kind: Standard; ComponentLink1Description: https://datasheet.ciiva.com:443/pdfs/VipMasterIC/IC/VISH/VISH-S-A0009736188/VISH-S-A0009736188-1.pdf?src-supplier=IHS+Markit; ComponentLink1URL: https://datasheet.ciiva.com:443/pdfs/VipMasterIC/IC/VISH/VISH-S-A0009736188/VISH-S-A0009736188-1.pdf?src-supplier=IHS+Markit; ComponentLink2Description: https://datasheet.ciiva.com/8997/wslhigh-8997164.pdf?src-supplier=Avnet; ComponentLink2URL: https://datasheet.ciiva.com/8997/wslhigh-8997164.pdf?src-supplier=Avnet; Construction: Rectangular; JESD-609 Code: e3; Library Name: Components.SchLib; Manufacturer Part Number: WSL1206R0600FEA18; Max Operating Temperature: 170°C; Min Operating Temperature: -65°C; Mounting Technology: Surface Mount; Package Style: SMTMeter; Packaging: Tape and Reel; Pins: 2; Pricing: 1=1.05, 10=0.929, 25=0.854, 50=0.779, 100=0.6742, 250=0.56932, 500=0.47944, 1000=0.39853 (USD); Reach SVHC Compliant: Yes; Resistor Type: FIXED RESISTOR; RoHS: Yes; RoHS Compliant: Yes; Stock: 13911; Supplier: Digi-Key; Supplier Part Number: 541-10320-1-ND; Technology: METAL STRIP; Terminal Finish: Nickel; Termination: Wraparound; Tolerance: 1%
R303 R306-R310 = CRCW0402100KFKED: Thick Film Resistors - SMD 1/16watt 100Kohms 1%; Manufacturer: Vishay; Package Height: 0.35mm; Package Length: 1mm; Package Width: 0.5mm; Power: 63mW; Rated Temperature: 70°C; Reference Standard: AEC-Q200; Temperature Coefficient: 100ppm/°C; Value: 100kR; Working Voltage: 50V; Category: Chip SMD Resistors; Component Kind: Standard; ComponentLink1Description: https://datasheet.ciiva.com:443/pdfs/VipMasterIC/IC/VISH/VISH-S-A0007266705/VISH-S-A0007266705-1.pdf?src-supplier=IHS+Markit; ComponentLink1URL: https://datasheet.ciiva.com:443/pdfs/VipMasterIC/IC/VISH/VISH-S-A0007266705/VISH-S-A0007266705-1.pdf?src-supplier=IHS+Markit; ComponentLink2Description: https://datasheet.ciiva.com/11810/0900766b80d98824-11810752.pdf?src-supplier=Rs; ComponentLink2URL: https://datasheet.ciiva.com/11810/0900766b80d98824-11810752.pdf?src-supplier=Rs; ComponentLink3Description: https://datasheet.ciiva.com/46441/1720486-46441598.pdf?src-supplier=Element14; ComponentLink3URL: https://datasheet.ciiva.com/46441/1720486-46441598.pdf?src-supplier=Element14; ComponentLink4Description: https://datasheet.ciiva.com/26316/fld-crcw-e3-data-en-26316630.pdf?src-supplier=Distrelec; ComponentLink4URL: https://datasheet.ciiva.com/26316/fld-crcw-e3-data-en-26316630.pdf?src-supplier=Distrelec; ComponentLink5Description: https://datasheet.ciiva.com/2463/dcrcwe3-2463064.pdf?src-supplier=Digi-Key; ComponentLink5URL: https://datasheet.ciiva.com/2463/dcrcwe3-2463064.pdf?src-supplier=Digi-Key; ComponentLink6Description: https://datasheet.ciiva.com/16776/70201119-16776281.pdf?src-supplier=Allied+Electronics; ComponentLink6URL: https://datasheet.ciiva.com/16776/70201119-16776281.pdf?src-supplier=Allied+Electronics; ComponentLink7Description: https://datasheet.ciiva.com/3255/dcrcwe3-3255947.pdf?src-supplier=Avnet; ComponentLink7URL: https://datasheet.ciiva.com/3255/dcrcwe3-3255947.pdf?src-supplier=Avnet; ComponentLink8Description: https://datasheet.ciiva.com/2463/dcrcwe3-2463065.pdf?src-supplier=Digi-Key; ComponentLink8URL: https://datasheet.ciiva.com/2463/dcrcwe3-2463065.pdf?src-supplier=Digi-Key; ComponentLink9Description: https://datasheet.ciiva.com/2463/dcrcwe3-2463066.pdf?src-supplier=Digi-Key; ComponentLink9URL: https://datasheet.ciiva.com/2463/dcrcwe3-2463066.pdf?src-supplier=Digi-Key; ComponentLink10Description: https://datasheet.ciiva.com/5546/dcrcwe3-109170-5546638.pdf?src-supplier=Mouser; ComponentLink10URL: https://datasheet.ciiva.com/5546/dcrcwe3-109170-5546638.pdf?src-supplier=Mouser; ComponentLink11Description: https://datasheet.ciiva.com/3255/dcrcwe3-3255948.pdf?src-supplier=Avnet; ComponentLink11URL: https://datasheet.ciiva.com/3255/dcrcwe3-3255948.pdf?src-supplier=Avnet; ComponentLink12Description: https://datasheet.ciiva.com/16208/dcrcwe3-16208508.pdf?src-supplier=Future+Electronics; ComponentLink12URL: https://datasheet.ciiva.com/16208/dcrcwe3-16208508.pdf?src-supplier=Future+Electronics; Construction: Chip; JESD-609 Code: e3; Library Name: Components.IntLib; Manufacturer Part Number: CRCW0402100KFKED; Max Operating Temperature: 155°C; Min Operating Temperature: -55°C; Mounting Technology: Surface Mount; Package Shape: Rectangular; Package Style: SMTMeter; Packaging: Tape and Reel; Pins: 2; Pricing: 1=0.1, 10=0.019, 50=0.019, 100=0.009, 1000=0.006, 10000=0.004 (USD); Reach SVHC Compliant: Yes; Resistor Type: FIXED RESISTOR; RoHS: Yes; RoHS Compliant: Yes; Stock: 615419; Supplier: Mouser; Supplier Part Number: 71-CRCW0402-100K-E3; Technology: METAL GLAZE/THICK FILM; Terminal Finish: Nickel; Termination: Wraparound; Tolerance: 1%
R304 R305 = CRCW0402120RFKED: 120 Ohms ±1% 0.063W, 1/16W Chip Resistor 0402 (1005 Metric) Automotive AEC-Q200 Thick Film; Manufacturer: Vishay; Category: Chip SMD Resistors; Component Kind: Standard; ComponentLink1Description: https://datasheet.ciiva.com/11817/0900766b80d98824-11817989.pdf?src-supplier=Rs; ComponentLink1URL: https://datasheet.ciiva.com/11817/0900766b80d98824-11817989.pdf?src-supplier=Rs; ComponentLink2Description: https://datasheet.ciiva.com/2463/dcrcwe3-2463110.pdf?src-supplier=Digi-Key; ComponentLink2URL: https://datasheet.ciiva.com/2463/dcrcwe3-2463110.pdf?src-supplier=Digi-Key; ComponentLink3Description: https://datasheet.ciiva.com/2463/dcrcwe3-2463109.pdf?src-supplier=Digi-Key; ComponentLink3URL: https://datasheet.ciiva.com/2463/dcrcwe3-2463109.pdf?src-supplier=Digi-Key; ComponentLink4Description: https://datasheet.ciiva.com/2463/dcrcwe3-2463111.pdf?src-supplier=Digi-Key; ComponentLink4URL: https://datasheet.ciiva.com/2463/dcrcwe3-2463111.pdf?src-supplier=Digi-Key; ComponentLink5Description: https://datasheet.ciiva.com/3256/dcrcwe3-3256009.pdf?src-supplier=Avnet; ComponentLink5URL: https://datasheet.ciiva.com/3256/dcrcwe3-3256009.pdf?src-supplier=Avnet; ComponentLink6Description: https://datasheet.ciiva.com/8336/dcrcwe3-8336661.pdf?src-supplier=Avnet; ComponentLink6URL: https://datasheet.ciiva.com/8336/dcrcwe3-8336661.pdf?src-supplier=Avnet; ComponentLink7Description: https://datasheet.ciiva.com/22674/1912744-22674687.pdf?src-supplier=Element14; ComponentLink7URL: https://datasheet.ciiva.com/22674/1912744-22674687.pdf?src-supplier=Element14; ComponentLink8Description: https://datasheet.ciiva.com/7777/1697337-7777194.pdf?src-supplier=Element14; ComponentLink8URL: https://datasheet.ciiva.com/7777/1697337-7777194.pdf?src-supplier=Element14; ComponentLink9Description: https://datasheet.ciiva.com/7777/1697337-7777195.pdf?src-supplier=Element14; ComponentLink9URL: https://datasheet.ciiva.com/7777/1697337-7777195.pdf?src-supplier=Element14; ComponentLink10Description: https://datasheet.ciiva.com/5550/dcrcwe3-109170-5550502.pdf?src-supplier=Mouser; ComponentLink10URL: https://datasheet.ciiva.com/5550/dcrcwe3-109170-5550502.pdf?src-supplier=Mouser; Library Name: Components.IntLib; Manufacturer Part Number: CRCW0402120RFKED; Pricing: 1=0.1, 10=0.033, 50=0.0182, 100=0.0134, 500=0.00818, 1000=0.00602, 5000=0.00432 (USD); RoHS: Yes; Stock: 24743; Supplier: Digi-Key; Supplier Part Number: 541-120LCT-ND
U300 = TPS563211DRLR: Buck Switching Regulator IC Positive Adjustable 0.6V 1 Output 3A SOT-583; Manufacturer: Texas Instruments; Category: Voltage Regulators - Switching; Component Kind: Standard; Library Name: IC_chips.IntLib; Manufacturer Part Number: TPS563211DRLR; Pricing: 1=0.44, 10=0.379, 25=0.3536, 100=0.2828, 250=0.2626, 500=0.2222, 1000=0.1717 (USD); RoHS: No; Stock: 10791; Supplier: Digi-Key; Supplier Part Number: 296-TPS563211DRLRCT-ND
U301 = INA186A2QDBVRQ1: Current Sense Amplifier 1 Circuit Rail-to-Rail TSOT-23-8; Manufacturer: Texas Instruments; Category: Amplifiers - Op Amps, Buffer, Instrumentation; Component Kind: Standard; Library Name: IC_chips.IntLib; Manufacturer Part Number: INA186A2QDBVRQ1; Pricing: 1=1.5, 10=1.349, 25=1.2728, 100=1.0843, 250=1.01808, 500=0.89082, 1000=0.73811 (USD); RoHS: Yes; Stock: 5988; Supplier: Digi-Key; Supplier Part Number: 296-INA186A2QDBVRQ1CT-ND
U302 U303 = TJA1051TK/3,118: 1/1 Transceiver Half CANbus 8-HVSON (3x3); Manufacturer: NXP Semiconductors; Channels: 1; Data Rate: 1000Mbps; Max Supply Current: 70uA; Nominal Supply Voltage: 5V; Package Body Material: Plastic; Package Equivalence Code: SOLCC8,.12,25; Qualification Status: Not Qualified; Telecom IC Type: CAN TRANSCEIVER; Case/Package: HVSON; Category: Interface ICs; Component Kind: Standard; ComponentLink1Description: https://datasheet.ciiva.com:443/pdfs/VipMasterIC/IC/PHGL/PHGLS22584/PHGLS22584-1.pdf?src-supplier=IHS+Markit; ComponentLink1URL: https://datasheet.ciiva.com:443/pdfs/VipMasterIC/IC/PHGL/PHGLS22584/PHGLS22584-1.pdf?src-supplier=IHS+Markit; ComponentLink2Description: https://datasheet.ciiva.com/12097/tja1051-12097722.pdf?src-supplier=Mouser; ComponentLink2URL: https://datasheet.ciiva.com/12097/tja1051-12097722.pdf?src-supplier=Mouser; ComponentLink3Description: https://datasheet.ciiva.com/11212/tja1051-11212765.pdf?src-supplier=Avnet; ComponentLink3URL: https://datasheet.ciiva.com/11212/tja1051-11212765.pdf?src-supplier=Avnet; Height - Seated (Max): 1mm; JESD-30 Code: S-PDSO-N8; JESD-609 Code: e4; Length: 3mm; Library Name: IC_chips.IntLib; Manufacturer Part Number: TJA1051TK/3,118; Moisture Sensitivity Level: 1; Number of Transceivers: 1; Package Shape: Square; Package Style: SMALL OUTLINE, HEAT SINK/SLUG, VERY THIN PROFILEMeter; Pins: 8; Power Supplies: 5V; Pricing 1: 1=1.68, 10=1.5, 50=1.4, 100=1.17, 1000=0.761, 10000=0.71 (USD); Reach SVHC Compliant: Yes; RoHS: Yes; RoHS Compliant: Yes; Stock 1: 92686; Supplier 1: Mouser; Supplier Part Number 1: 771-TJA1051TK/3118; Surface Mount: Yes; Terminal Finish: Nickel; Terminal Form: No Lead; Terminal Pitch: 0.65mm; Terminal Position: DUAL; Width: 3mm
U304 = FM25V02A-G: FRAM (Ferroelectric RAM) Memory IC 256Kbit SPI 40 MHz 8-SOIC; Manufacturer: Infineon; Component Kind: Standard; ComponentLink1Description: https://datasheet.octopart.com/FM25V02A-G-Infineon-datasheet-82516564.pdf?src-supplier=TME; ComponentLink1URL: https://datasheet.octopart.com/FM25V02A-G-Infineon-datasheet-82516564.pdf?src-supplier=TME; Library Name: IC_chips.IntLib; Manufacturer Part Number: FM25V02A-G; Pricing 1: 1=9.21, 10=6.36, 50=5.69, 100=5.11, 1000=4.57, 10000=4.57 (USD); RoHS: No; Stock 1: 6904; Supplier 1: Mouser; Supplier Part Number 1: 727-FM25V02A-G
